# Altium schematic sheet ADC.SchDoc(ADC)
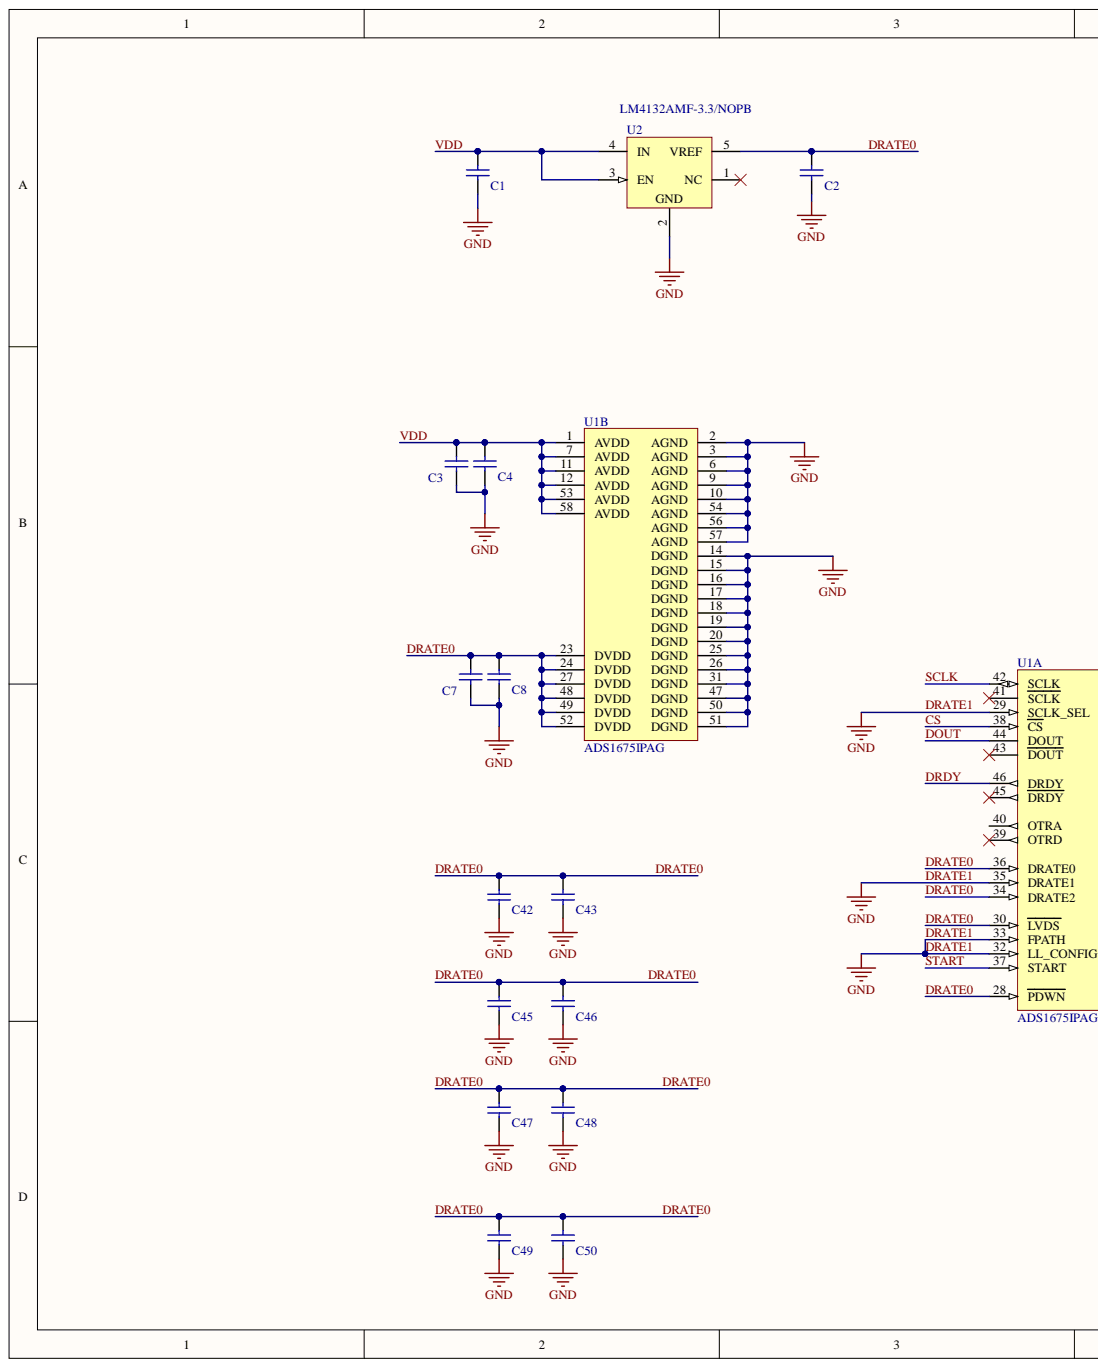
[diagram p0: ADC.SchDoc, left]
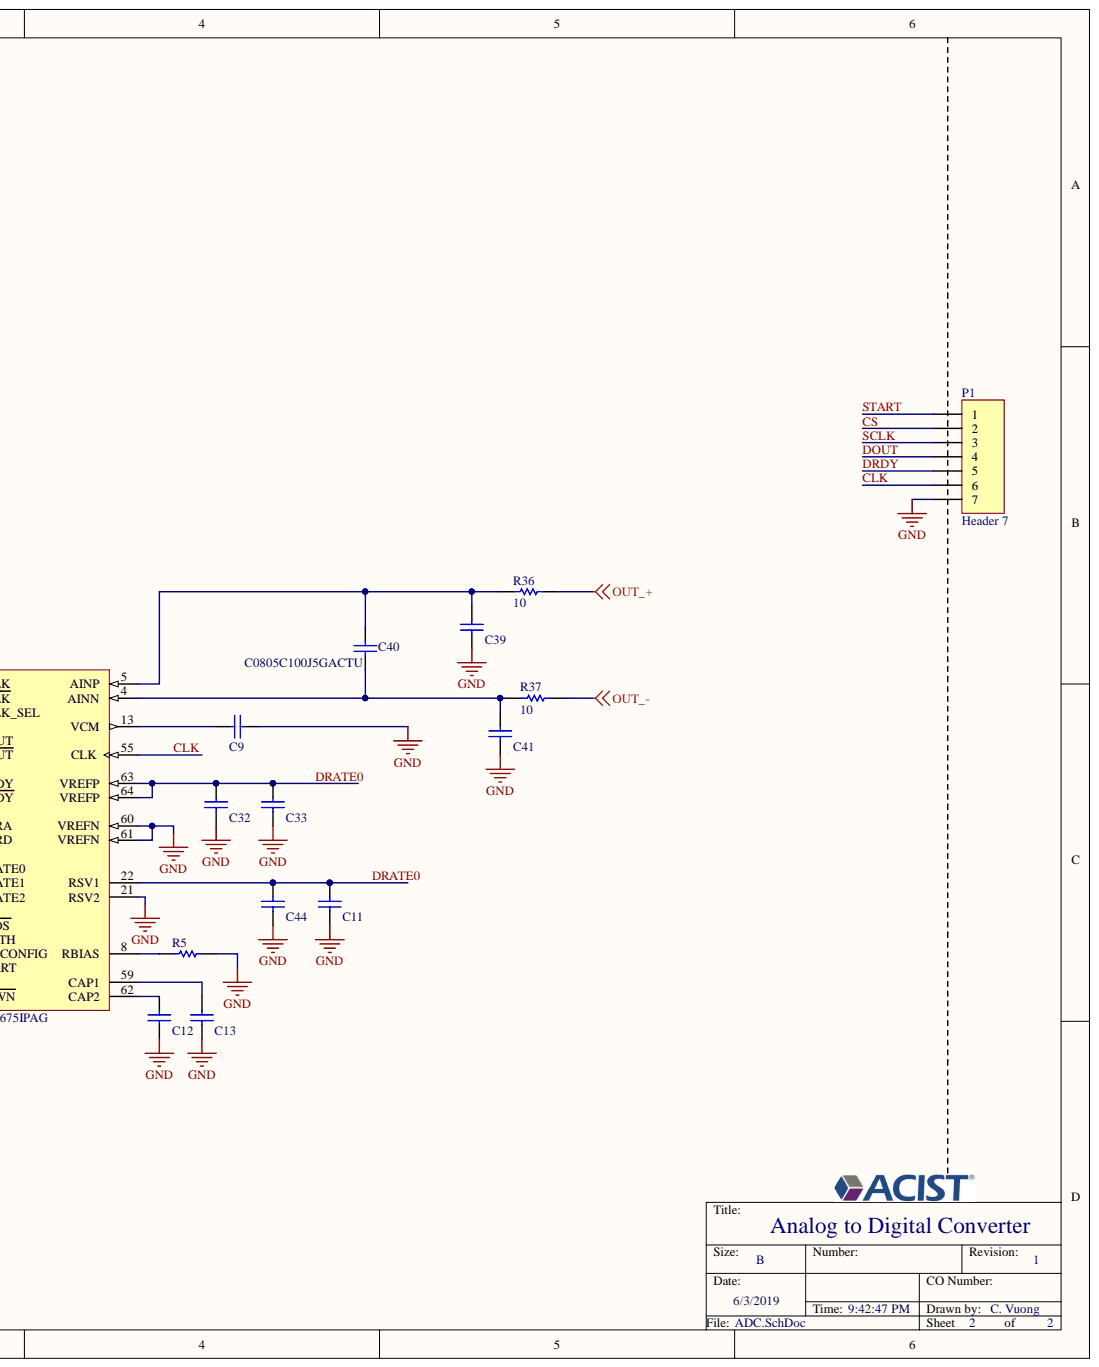
[diagram p1: ADC.SchDoc, right]

## Parts
C1  C1608X5R1A106K080AC  [CAPC1608X90X20ML10]  (value not drawn)
C2  C1608X5R1A106K080AC  [CAPC1608X90X20ML10]  (value not drawn)
C3  C1608X5R1A106K080AC  [CAPC1608X90X20ML10]  (value not drawn)
C4  C1608X5R1A106K080AC  [CAPC1608X90X20ML10]  (value not drawn)
C7  C1608X5R1A106K080AC  [CAPC1608X90X20ML10]  (value not drawn)
C8  C1608X5R1A106K080AC  [CAPC1608X90X20ML10]  (value not drawn)
C9  C1608X5R1A106K080AC  [CAPC1608X90X20ML10]  (value not drawn)
C11  C1608X5R1A106K080AC  [CAPC1608X90X20ML10]  (value not drawn)
C12  C1608X5R1A106K080AC  [CAPC1608X90X20ML10]  (value not drawn)
C13  C1608X5R1A106K080AC  [CAPC1608X90X20ML10]  (value not drawn)
C32  C1608X5R1A106K080AC  [CAPC1608X90X20ML10]  (value not drawn)
C33  C1608X5R1A106K080AC  [CAPC1608X90X20ML10]  (value not drawn)
C39  C1608X5R1A106K080AC  [CAPC1608X90X20ML10]  (value not drawn)
C40  C0805C100J5GACTU  [CAPC2013X88X50LL20T25]
C41  C1608X5R1A106K080AC  [CAPC1608X90X20ML10]  (value not drawn)
C42  C1608X5R1A106K080AC  [CAPC1608X90X20ML10]  (value not drawn)
C43  C1608X5R1A106K080AC  [CAPC1608X90X20ML10]  (value not drawn)
C44  C1608X5R1A106K080AC  [CAPC1608X90X20ML10]  (value not drawn)
C45  C1608X5R1A106K080AC  [CAPC1608X90X20ML10]  (value not drawn)
C46  C1608X5R1A106K080AC  [CAPC1608X90X20ML10]  (value not drawn)
C47  C1608X5R1A106K080AC  [CAPC1608X90X20ML10]  (value not drawn)
C48  C1608X5R1A106K080AC  [CAPC1608X90X20ML10]  (value not drawn)
C49  C1608X5R1A106K080AC  [CAPC1608X90X20ML10]  (value not drawn)
C50  C1608X5R1A106K080AC  [CAPC1608X90X20ML10]  (value not drawn)
P1  Header 7  [HDR1X7]
R5  RR0816P-241-D  [RESC1608X50X30LL20T20]  (value not drawn)
R36  10  [RESC1608X50X30LL20T20]
R37  10  [RESC1608X50X30LL20T20]
U1  ADS1675IPAG  [TI-PAG64_L]  (parts A,B)
U2  LM4132AMF-3.3/NOPB  [DBV0005A_L]

## Nets
OUT_+: R36.1
OUT_-: R37.1
CLK: P1.6 U1.55(CLK)
CS: P1.2 U1.38(CS)
DOUT: P1.4 U1.44(DOUT)
DRATE0: C2.1 C7.1 C8.1 C11.1 C32.1 C33.1 C42.1 C43.1 C44.1 C45.1 C46.1 C47.1 C48.1 C49.1 C50.1 U1.22(RSV1) U1.23(DVDD) U1.24(DVDD) U1.27(DVDD) U1.28(PDWN) U1.30(LVDS) U1.34(DRATE2) U1.36(DRATE0) U1.48(DVDD) U1.49(DVDD) U1.52(DVDD) U1.63(VREFP) U1.64(VREFP) U2.5(VREF)
DRATE1: C1.2 C2.2 C3.2 C4.2 C7.2 C8.2 C9.2 C11.2 C12.2 C13.2 C32.2 C33.2 C39.2 C41.2 C42.2 C43.2 C44.2 C45.2 C46.2 C47.2 C48.2 C49.2 C50.2 P1.7 R5.2 U1.2(AGND) U1.3(AGND) U1.6(AGND) U1.9(AGND) U1.10(AGND) U1.14(DGND) U1.15(DGND) U1.16(DGND) U1.17(DGND) U1.18(DGND) U1.19(DGND) U1.20(DGND) U1.21(RSV2) U1.25(DGND) U1.26(DGND) U1.29(SCLK_SEL) U1.31(DGND) U1.32(LL_CONFIG) U1.33(FPATH) U1.35(DRATE1) U1.47(DGND) U1.50(DGND) U1.51(DGND) U1.54(AGND) U1.56(AGND) U1.57(AGND) U1.60(VREFN) U1.61(VREFN) U2.2
DRDY: P1.5 U1.46(DRDY)
SCLK: P1.3 U1.42(SCLK)
START: P1.1 U1.37(START)
VDD: C1.1 C3.1 C4.1 U1.1(AVDD) U1.7(AVDD) U1.11(AVDD) U1.12(AVDD) U1.53(AVDD) U1.58(AVDD) U2.3(EN) U2.4(IN)
NetC9_1: C9.1 U1.13(VCM)
NetC12_1: C12.1 U1.62(CAP2)
NetC13_1: C13.1 U1.59(CAP1)
NetC39_1: C39.1 C40.2 R36.2 U1.5(AINP)
NetC40_1: C40.1 C41.1 R37.2 U1.4(AINN)
NetR5_1: R5.1 U1.8(RBIAS)

Not connected: U1 39(OTRD) 40(OTRA) 41(SCLK) 43(DOUT) 45(DRDY); U2 1(NC)

## Library data of the parts
C1-C4 C7-C9 C11-C13 C32 C33 C39 C41-C50 = CMP-2000-04979-1: Chip Capacitor, 10 uF, +/- 10%, 10 V, -55 to 85 degC, 0603 (1608 Metric), RoHS, Tape and Reel; PCN Part Number: MLCCPartNumberChange30/Nov/2012; Manufacturer: TDK; Voltage - Rated: 10V; Temperature Coefficient: X5R; Product Training Modules: CSeriesGeneralApplicationsSEAT,CCV,andTVCLDesignToolsGuidetoReplacinganElectrolyticCapacitorwithanMLCC; Other Names: 445-7486-1; Online Catalog: CSeries,GeneralPurpose; Kits: 445-9003-KIT-ND-KITCAPCERHICAPMLCCSMD; Design Resources: 20WNon-IsolatedBuckLEDDriverUsingPLLNK460KG; Capacitance: 10µF; Tolerance: ±10%; Thickness (Max): 0.035"(0.90mm); Standard Package: 1; Size / Dimension: 0.063"Lx0.031"W(1.60mmx0.80mm); Series: C; RoHS Information: RoHSCertificate-MLCC; Ratings: -; Packaging: CutTape(CT); Package Reference: 603; Package Description: 2-Pin Surface Mount Device, Body 1.6 x 0.8 mm; Package / Case: 0603(1608Metric); Operating Temperature: -55degC~85degC; Mounting Type: SurfaceMount,MLCC; Manufacturer URL: http://www.tdk.com/; Library Name: Altium Content Vault; Lead Style: -; Lead Spacing: -; Height - Seated (Max): -; Features: -; Family: CeramicCapacitors; Datasheet Version: Mar-15; Datasheet URL: http://download.siliconexpert.com/pdfs/2015/4/21/15/54/45/779/tdk_/manual/37024850441143360mlcc_commercial_general_en.pdf; Component Kind: Standard; Category: Capacitors; Applications: GeneralPurpose
C40 = CMP-2007-00219-1: Manufacturer: Kemet; Part Number: C0805C100J5GACTU; Library Name: Altium Content Vault; Component Kind: Standard
P1 = Header 7: Header, 7-Pin; Signal Integrity: Connector; Library Name: Miscellaneous Connectors.IntLib; LatestRevisionNote: Re-released for DXP Platform.; LatestRevisionDate: 17-Jul-2002; Component Kind: Standard; Publisher: Altium Limited
R5 = CMP-2000-02559-1: Manufacturer: Susumu; Part Number: RR0816P-241-D; Library Name: Altium Content Vault; Component Kind: Standard
R36 R37 = CMP-2000-02559-1: Part Number: RR0816P-241-D; Manufacturer: Susumu; Library Name: Altium Content Vault; Component Kind: Standard
U1 = TI-ADS1675PAG64: 4 MSPS, 24-Bit ADC, -40 to 85 degC, 64-pin QFP (PAG64), Green (RoHS & no Sb/Br); Manufacturer: Texas Instruments; SubFamily: Precision ADC (<=10MSPS); SNR(dB): 92; SFDR(dB): 120; Sample Rate (max)(SPS): 4M; Resolution(Bits): 24; Interface: Parallel LVDS, Serial SPI; Digital Supply(Min)(V): 2.85; Digital Supply(Max)(V): 3.15; Architecture: Delta-Sigma; Analog Voltage AV/DD(Min)(V): 4.75; Analog Voltage AV/DD(Max)(V): 5.25; # Input Channels: 1; PartNumber: ADS1675IPAG; PackageVersion: 4040282/C, 11/1996; PackageReference: PAG64; PackageDescription: 64-Pin Plastic Flat Pack, Body 10 x 10 mm, Pitch 0.50 mm; Mounting Technology: Surface Mount; Library Name: Project X_1.SCHLIB; Eco-Plan: Green (RoHS & no Sb/Br); DatasheetVersion: SBAS416D; ComponentLink3URL: http://www.ti.com/lit/ml/mtqf006a/mtqf006a.pdf; ComponentLink3Description: Package Specification; ComponentLink2URL: http://www.ti.com/general/docs/lit/getliterature.tsp?genericPartNumber=ADS1675&fileType=pdf; ComponentLink2Description: Datasheet; ComponentLink1URL: http://www.ti.com/; ComponentLink1Description: Manufacturer URL; Component Kind: Standard; Code_JEDEC: MS-026
U2 = CMP-0074-00542-5: Precision Low Dropout Voltage Reference, 5-pin SOT-23, Pb-Free; Manufacturer: Texas Instruments; PowerWise: Y; PackageTopMarking: R4EA*XTT; CompType: Voltage Reference IC; RoHS: Y; RevisionNote: PowerWise parameter updated.; PinCount: 5; PartNumber: LM4132AMF-3.3/NOPB; PackageVersion: revD, Aug-2008; PackageReference: DBV0005A; PackageDescription: Molded SOT-23, .115 x .063 x .040 in Body, 5 Lead, .0375 in Pitch; Library Name: Altium Content Vault; High-Reliability: Y; DatasheetVersion: Aug-2006; ComponentLink1URL: http://www.ti.com/general/docs/lit/getliterature.tsp?genericPartNumber=LM4132&fileType=pdf; ComponentLink1Description: Datasheet; Component Kind: Standard
